# Altium schematic sheet 11 - POWER, RESET.SchDoc("11 - POWER, RESET")
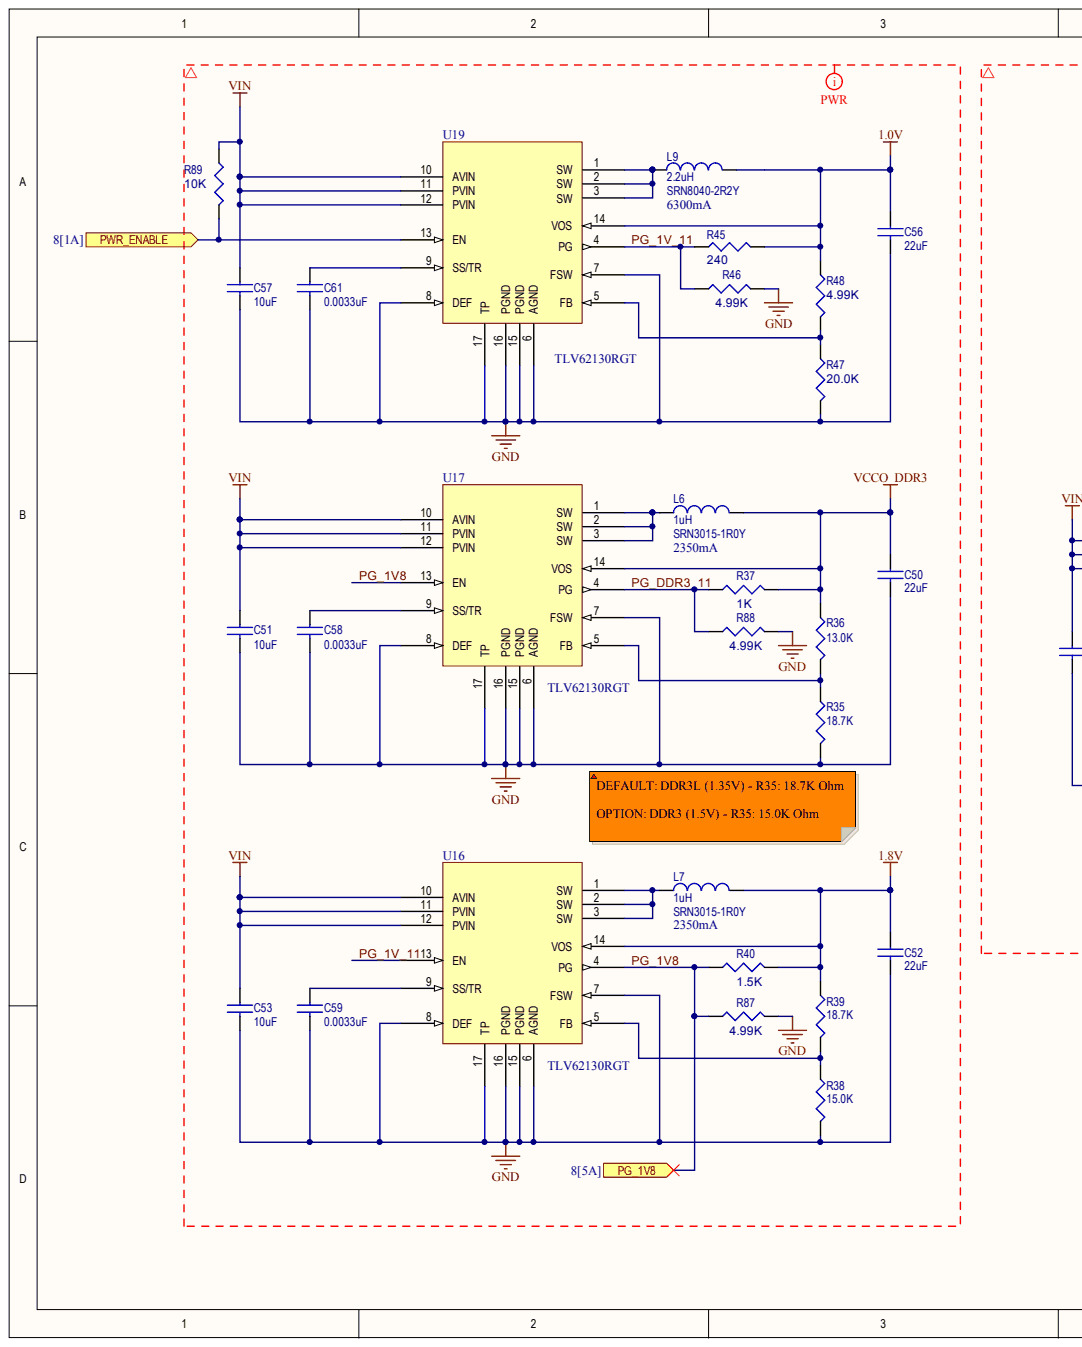
[diagram p0: 11 - POWER, RESET.SchDoc, left]
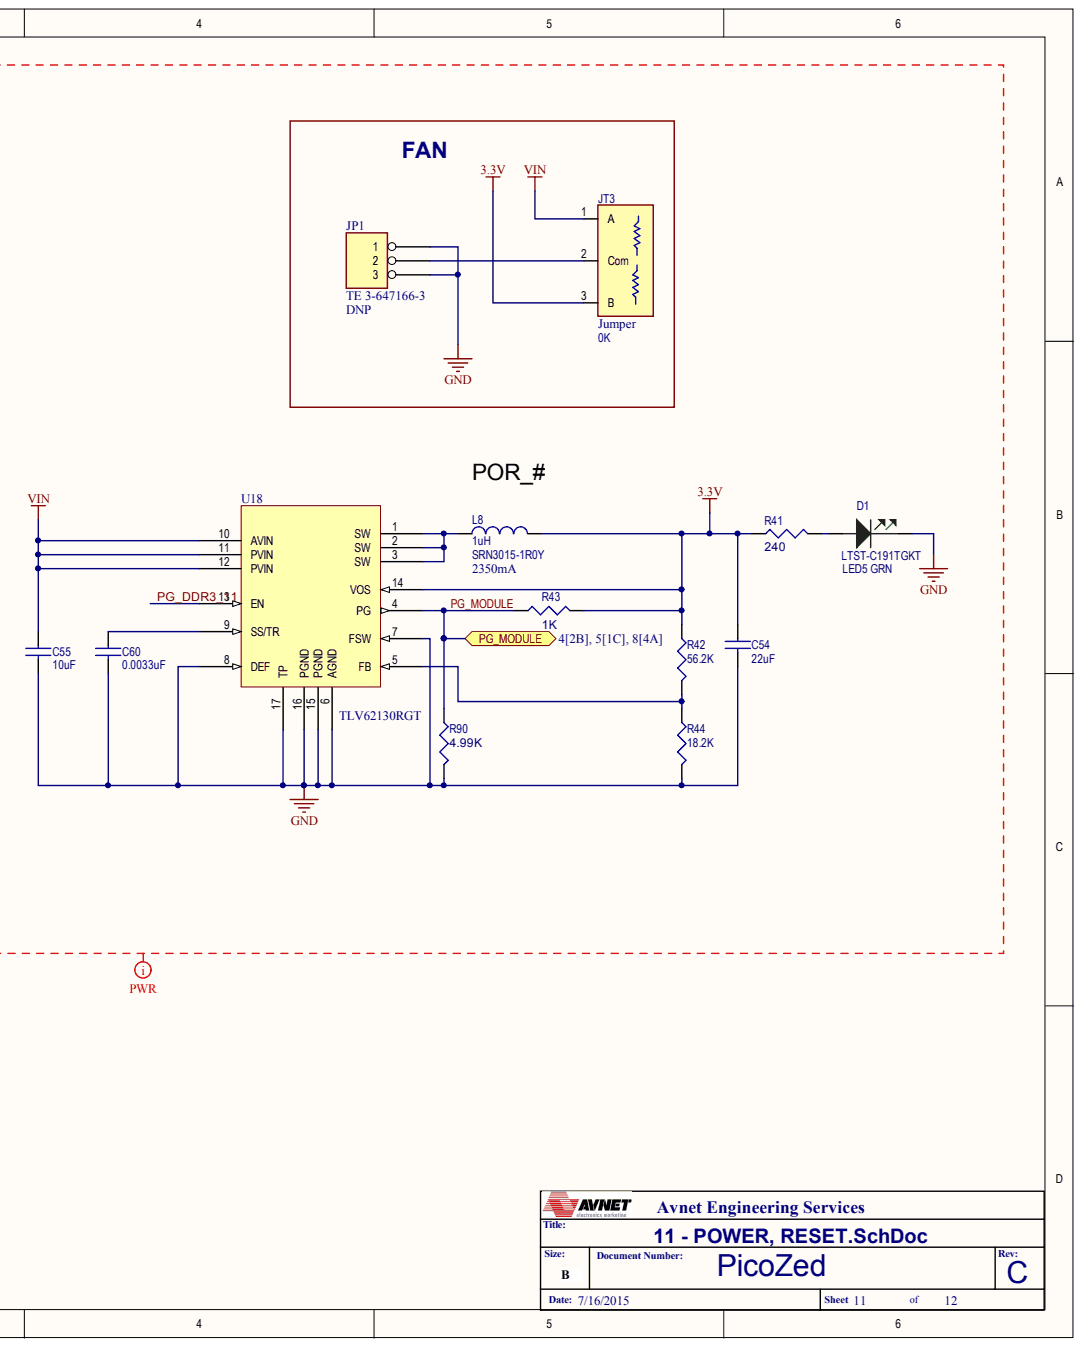
[diagram p1: 11 - POWER, RESET.SchDoc, right]

## Parts
C50  value 22uF  [C0805]  (hidden comment: EMK212BBJ226MG-T)
C51  value 10uF  [C1206]  (hidden comment: PC219)
C52  value 22uF  [C0805]  (hidden comment: EMK212BBJ226MG-T)
C53  value 10uF  [C1206]  (hidden comment: PC219)
C54  value 22uF  [C0805]  (hidden comment: EMK212BBJ226MG-T)
C55  value 10uF  [C1206]  (hidden comment: PC219)
C56  value 22uF  [C0805]  (hidden comment: EMK212BBJ226MG-T)
C57  value 10uF  [C1206]  (hidden comment: PC219)
C58  value 0.0033uF  [C0402]  (hidden comment: PC190)
C59  value 0.0033uF  [C0402]  (hidden comment: PC190)
C60  value 0.0033uF  [C0402]  (hidden comment: PC190)
C61  value 0.0033uF  [C0402]  (hidden comment: PC190)
D1  Color: GRN  Device: LTST-C191TGKT  Text: LED5  [LED_LITE-LTST-C191TBKT-G]  (hidden comment: DL018)
JP1  TE 3-647166-3  [3-647166-3]
JT3  value 0K  Device: Jumper  [R0402-DUAL_JMP]
L6  SRN3015-1R0Y  value 1uH  Device: 1uH  Imax: 2350mA  [IND_SRN3015]
L7  SRN3015-1R0Y  value 1uH  Device: 1uH  Imax: 2350mA  [IND_SRN3015]
L8  SRN3015-1R0Y  value 1uH  Device: 1uH  Imax: 2350mA  [IND_SRN3015]
L9  SRN8040-2R2Y  value 2.2uH  Device: 2.2uH  [IND_SRN8040]
R35  value 18.7K  [R0402]  (hidden comment: PR536)
R36  value 13.0K  [R0402]  (hidden comment: PR043)
R37  1K  [R0402]
R38  value 15.0K  [R0402]  (hidden comment: PR046)
R39  value 18.7K  [R0402]  (hidden comment: PR536)
R40  1.5K  [R0402]
R41  240  [R0402]
R42  value 56.2K  [R0402]  (hidden comment: PR101)
R43  1K  [R0402]
R44  value 18.2K  [R0402]  (hidden comment: PR051)
R45  240  [R0402]
R46  4.99K  [R0402]
R47  20.0K  [R0402]
R48  4.99K  [R0402]
R87  4.99K  [R0402]
R88  4.99K  [R0402]
R89  10K  [R0402]
R90  4.99K  [R0402]
U16  TLV62130RGT  [QFN16_3X3]
U17  TLV62130RGT  [QFN16_3X3]
U18  TLV62130RGT  [QFN16_3X3]
U19  TLV62130RGT  [QFN16_3X3]

## Ports
PG_1V8, PG_MODULE, PWR_ENABLE

## Nets
1.0V: C56.1 L9.2 R45.2 R48.1 U19.14(VOS)
1.8V: C52.1 L7.2 R39.1 R40.1 U16.14(VOS)
3.3V: C54.1 JT3.3 L8.2 R41.1 R42.1 R43.1 U18.14(VOS)
GND: C50.2 C51.2 C52.2 C53.2 C54.2 C55.2 C56.2 C57.2 C58.2 C59.2 C60.2 C61.2 D1.C JP1.1 JP1.3 R35.2 R38.2 R44.2 R46.2 R47.2 R87.2 R88.2 R90.2 U16.6(AGND) U16.7(FSW) U16.8(DEF) U16.15(PGND) U16.16(PGND) U16.17(TP) U17.6(AGND) U17.7(FSW) U17.8(DEF) U17.15(PGND) U17.16(PGND) U17.17(TP) U18.6(AGND) U18.7(FSW) U18.8(DEF) U18.15(PGND) U18.16(PGND) U18.17(TP) U19.6(AGND) U19.7(FSW) U19.8(DEF) U19.15(PGND) U19.16(PGND) U19.17(TP)
VCCO_DDR3: C50.1 L6.2 R36.1 R37.1 U17.14(VOS)
VIN: C51.1 C53.1 C55.1 C57.1 JT3.1 R89.1 U16.10(AVIN) U16.11(PVIN) U16.12(PVIN) U17.10(AVIN) U17.11(PVIN) U17.12(PVIN) U18.10(AVIN) U18.11(PVIN) U18.12(PVIN) U19.10(AVIN) U19.11(PVIN) U19.12(PVIN)
PG_1V8: R40.2 R87.1 U16.4(PG) U17.13(EN) ; port PG_1V8
PG_1V_11: R45.1 R46.1 U16.13(EN) U19.4(PG)  [also labelled PG_1V]
PG_DDR3_11: R37.2 R88.1 U17.4(PG) U18.13(EN)  [also labelled PG_DDR3]
PG_MODULE: R43.2 R90.1 U18.4(PG) ; port PG_MODULE
PWR_ENABLE: R89.2 U19.13(EN) ; port PWR_ENABLE
NetC58_1: C58.1 U17.9(SS/TR)
NetC59_1: C59.1 U16.9(SS/TR)
NetC60_1: C60.1 U18.9(SS/TR)
NetC61_1: C61.1 U19.9(SS/TR)
NetD1_A: D1.A R41.2
NetJP1_2: JP1.2 JT3.2
NetL6_1: L6.1 U17.1(SW) U17.2(SW) U17.3(SW)
NetL7_1: L7.1 U16.1(SW) U16.2(SW) U16.3(SW)
NetL8_1: L8.1 U18.1(SW) U18.2(SW) U18.3(SW)
NetL9_1: L9.1 U19.1(SW) U19.2(SW) U19.3(SW)
NetR35_1: R35.1 R36.2 U17.5(FB)
NetR38_1: R38.1 R39.2 U16.5(FB)
NetR42_2: R42.2 R44.1 U18.5(FB)
NetR47_1: R47.1 R48.2 U19.5(FB)
N00661:
N00662:

## Library data of the parts
C50 C52 C54 C56 = (no symbol name): CAP SM 22uF 16V 20% X5R C0805; PartCode: PC; AsyTxt: PC112; AVLNo: AVPC-0000531; ESR: CAP-P; MFG Code: TAIYO YUDEN; Component Kind: Standard; ComponentLink1Description: Datasheet; Device: CAP; Dielectric: X5R; Library Name: Zynq_Z010_ZedStick.SchLib; MFGPN: EMK212BBJ226MG-T; PkgType: SM; RoHS: Yes; Status: Preliminary; Tol: 20%; Volt: 16V
C51 C53 C55 C57 = AVPC-0000219: CAP SM 10uF 25V 10% X5R C1206; PartCode: PC; Database Table Name: Passive-Cap$; MFG Code: AVX; MFGPN: 12063D106KAT2A; AsyTxt: PC219; AVLNo: AVPC-0000219; Component Kind: Standard; ComponentLink1Description: Datasheet; ComponentLink2Description: MFR Website; ComponentLink2URL: www.avx.com; Device: CAP; Dielectric: X5R; ESR: CAP; PkgType: SM; RoHS: Yes; Status: Preliminary; Tol: 10%; Volt: 25V; ComponentLink1URL: C:\AVDBLIB\DATASHEETS\AVX_CX5R.pdf; Library Name: Avnet_DBlib.DbLib
C58-C61 = AVPC-0000190: CAP SM 0.0033uF 25V 5% X7R C0402; PartCode: PC; Database Table Name: Passive-Cap$; MFG Code: AVX; MFGPN: 04023C332JAT2A; AsyTxt: PC190; AVLNo: AVPC-0000190; Component Kind: Standard; ComponentLink1Description: Datasheet; ComponentLink2Description: MFR Website; ComponentLink2URL: www.avx.com; Device: CAP; Dielectric: X7R; ESR: CAP; PkgType: SM; RoHS: Yes; Status: Preliminary; Tol: 5%; Volt: 25V; ComponentLink1URL: C:\AVDBLIB\DATASHEETS\AVX_CX7R.pdf; Library Name: Avnet_DBlib.DbLib
D1 = AVDL-0000018: LED, GREEN  525nm  3.2V 20mA 150mcd  LED-G LED_LITE-LTST-C191TBKT-G; PartCode: DL; Database Table Name: Discrete-LED$; MFG Code: LTP; AsyTxt: DL018; AVLNo: AVDL-0000018; Component Kind: Standard; ComponentLink1Description: Datasheet; Device Type: LED, GREEN; If: 20mA; Intensity at If: 150mcd; MFGPN: LTST-C191TGKT; Status: Preliminary; Vf: 3.2V; WaveLength: 525nm; ComponentLink1URL: C:\AVDBLIB\DATASHEETS\LTST-C191TGKT.pdf; Library Name: Avnet_DBlib.DbLib
JP1 = TE 3-647166-3: CONN HEADER 3POS SMT; MFG Code: TE CONNECTIVITY; MFGPN: BSS138; Component Kind: Standard; DNP: DNP; Library Name: new.SchLib; Part #: 3-647166-3; Status: Preliminary
JT3 = AVPO-0000025: Jumper 3-pad 0402 RES - 0 Ohm : Pads 1-2; PartCode: PO; Database Table Name: Passive-Other$; Device Type: 3-pad 0R0 0402; MFG Code: DAL; MFGPN: CRCW04020000Z0ED; Note: Place Pads: 1-2; PkgType: SM; Component Kind: Standard; ComponentLink1Description: Datasheet; Part #: CRCW04020000Z0ED; RoHS: Yes; Status: Preliminary; ComponentLink1URL: C:\AVDBLIB\DATASHEETS\Dale_CRCW.pd; Library Name: Avnet_DBlib.DbLib
L6-L8 = (no symbol name): IND SM 1uH 2.35A DCR=48mOhm; PartCode: PI; AVLNo: AVPI-0000091; MFG Code: BNS; Component Kind: Standard; ComponentLink1Description: Datasheet; Library Name: Zynq_Z010_ZedStick.SchLib; MFGPN: SRN3015-1R0Y; PkgType: SM; Status: Preliminary
L9 = (no symbol name): IND SM 2.2uH 6.3A DCR=7mOhm; PartCode: PI; AVLNo: AVPI-0000092; Imax: 6300mA; MFG Code: BNS; Component Kind: Standard; ComponentLink1Description: Datasheet; Library Name: Zynq_Z010_ZedStick.SchLib; MFGPN: SRN8040-2R2Y; PkgType: SM; Status: Preliminary
R35 R39 = AVPR-0000536: RES SM 18.7K  62mW 0.01 R0402; PartCode: PR; Database Table Name: Passive-Res$; MFG Code: DAL; MFGPN: CRCW040218K7FKED; Tol: 0.01; AsyTxt: PR536; AVLNo: AVPR-0000536; Component Kind: Standard; ComponentLink1Description: Datasheet; Device: RES; PkgType: SM; RoHS: Yes; Status: Preliminary; Watt: 62mW; ComponentLink1URL: C:\AVDBLIB\DATASHEETS\Dale_CRCW.pd; Library Name: Avnet_DBlib.DbLib
R36 = AVPR-0000043: RES SM 13.0K 62mW 0.01 R0402; PartCode: PR; Database Table Name: Passive-Res$; MFG Code: DAL; MFGPN: CRCW040213K0FKED; Tol: 0.01; AsyTxt: PR043; AVLNo: AVPR-0000043; Component Kind: Standard; ComponentLink1Description: Datasheet; Device: RES; PkgType: SM; RoHS: Yes; Status: Preliminary; Watt: 62mW; ComponentLink1URL: C:\AVDBLIB\DATASHEETS\Dale_CRCW.pd; Library Name: Avnet_DBlib.DbLib
R37 R43 = AVPR-0000052: RES SM 1K 62mW 0.01 R0402; PartCode: PR; AsyTxt: PR052; Database Table Name: Passive-Res$; MFG Code: DAL; MFGPN: CRCW04021K00FKED; Tol: 0.01; AVLNo: AVPR-0000052; Component Kind: Standard; ComponentLink1Description: Datasheet; Device: RES; PkgType: SM; RoHS: Yes; Status: Preliminary; Value: 1K; Watt: 62mW; ComponentLink1URL: C:\AVDBLIB\DATASHEETS\Dale_CRCW.pd; Library Name: Avnet_DBlib.DbLib
R38 = AVPR-0000046: RES SM 15.0K 62mW 0.01 R0402; PartCode: PR; Database Table Name: Passive-Res$; MFG Code: DAL; MFGPN: CRCW040215K0FKED; Tol: 0.01; AsyTxt: PR046; AVLNo: AVPR-0000046; Component Kind: Standard; ComponentLink1Description: Datasheet; Device: RES; PkgType: SM; RoHS: Yes; Status: Preliminary; Watt: 62mW; ComponentLink1URL: C:\AVDBLIB\DATASHEETS\Dale_CRCW.pd; Library Name: Avnet_DBlib.DbLib
R40 = AVPR-0000052: RES SM 1.5K 62mW 0.01 R0402; PartCode: PR; Database Table Name: Passive-Res$; MFG Code: DAL; MFGPN: CRCW04021K50FKED; Tol: 0.01; Component Kind: Standard; Device: RES; PkgType: SM; RoHS: Yes; Status: Preliminary; Value: 1.5K; Watt: 62mW; Library Name: Avnet_DBlib.DbLib
R41 R45 = AVPR-0000511: RES SM 240 62mW 0.01 R0402; Alt1MFR: NIC; PartCode: PR; Alt1PN: NRC04F2400TRF; AsyTxt: PR511; Database Table Name: Passive-Res$; MFG Code: DAL; MFGPN: CRCW0402240RFKED; Power: 0; Tol: 0.01; Availability: 1M-AV; AVLNo: AVPR-0000511; Component Kind: Standard; ComponentLink1Description: Datasheet; Cost: .01; Device: RES; PkgType: SM; Received: 0; RoHS: Yes; Size: 1.9; Status: Preliminary; Value: 240; Watt: 62mW; ComponentLink1URL: C:\AVDBLIB\DATASHEETS\Dale_CRCW.pd; Library Name: Avnet_DBlib.DbLib
R42 = AVPR-0000101: RES SM 56.2K 62mW 0.01 R0402; PartCode: PR; Database Table Name: Passive-Res$; MFG Code: DAL; MFGPN: CRCW040256K2FKED; Tol: 0.01; AsyTxt: PR101; AVLNo: AVPR-0000101; Component Kind: Standard; ComponentLink1Description: Datasheet; Device: RES; PkgType: SM; RoHS: Yes; Status: Preliminary; Watt: 62mW; ComponentLink1URL: C:\AVDBLIB\DATASHEETS\Dale_CRCW.pd; Library Name: Avnet_DBlib.DbLib
R44 = AVPR-0000051: RES SM 18.2K 62mW 0.01 R0402; PartCode: PR; Database Table Name: Passive-Res$; MFG Code: DAL; MFGPN: CRCW040218K2FKED; Tol: 0.01; AsyTxt: PR051; AVLNo: AVPR-0000051; Component Kind: Standard; ComponentLink1Description: Datasheet; Device: RES; PkgType: SM; RoHS: Yes; Status: Preliminary; Watt: 62mW; ComponentLink1URL: C:\AVDBLIB\DATASHEETS\Dale_CRCW.pd; Library Name: Avnet_DBlib.DbLib
R46 R48 R87 R88 R90 = AVPR-0000094: RES SM 4.99K 62mW 0.01 R0402; PartCode: PR; AsyTxt: PR094; Database Table Name: Passive-Res$; MFG Code: DAL; MFGPN: CRCW04024K99FKED; Tol: 0.01; AVLNo: AVPR-0000094; Component Kind: Standard; ComponentLink1Description: Datasheet; Device: RES; PkgType: SM; RoHS: Yes; Status: Preliminary; Value: 4.99K; Watt: 62mW; ComponentLink1URL: C:\AVDBLIB\DATASHEETS\Dale_CRCW.pd; Library Name: Avnet_DBlib.DbLib
R47 = AVPR-0000061: RES SM 20.0K 62mW 0.01 R0402; PartCode: PR; AsyTxt: PR061; Database Table Name: Passive-Res$; MFG Code: DAL; MFGPN: CRCW040220K0FKED; Tol: 0.01; AVLNo: AVPR-0000061; Component Kind: Standard; ComponentLink1Description: Datasheet; Device: RES; PkgType: SM; RoHS: Yes; Status: Preliminary; Value: 20.0K; Watt: 62mW; ComponentLink1URL: C:\AVDBLIB\DATASHEETS\Dale_CRCW.pd; Library Name: Avnet_DBlib.DbLib
R89 = AVPR-0000034: RES SM 10.0K 62mW 0.01 R0402; PartCode: PR; AsyTxt: PR034; Database Table Name: Passive-Res$; MFG Code: DAL; MFGPN: CRCW040210K0FKED; Power: 0; Tol: 0.01; Watt: 63mW; Availability: 2M-AV; AVLNo: AVPR-0000034; CLASSNAME: DDR_TERM; Component Kind: Standard; ComponentLink1Description: Datasheet; Cost: .01; Device: RES; PkgType: SM; Received: 0; RoHS: Yes; Size: 1.9; Status: Preliminary; Value: 10K; Watt: 62mW; ComponentLink1URL: C:\AVDBLIB\DATASHEETS\Dale_CRCW.pd; Library Name: Avnet_DBlib.DbLib
U16-U19 = TLV62130RGT: Step Dowm Switcher, 3A, 3V-17V, Adjustable; MFG CODE: TIS; Component Kind: Standard; Library Name: Zynq_Z010_ZedStick.SchLib; MFGPN: TLV62130RGT
